# Altium schematic sheet RocketShield_pots.SchDoc
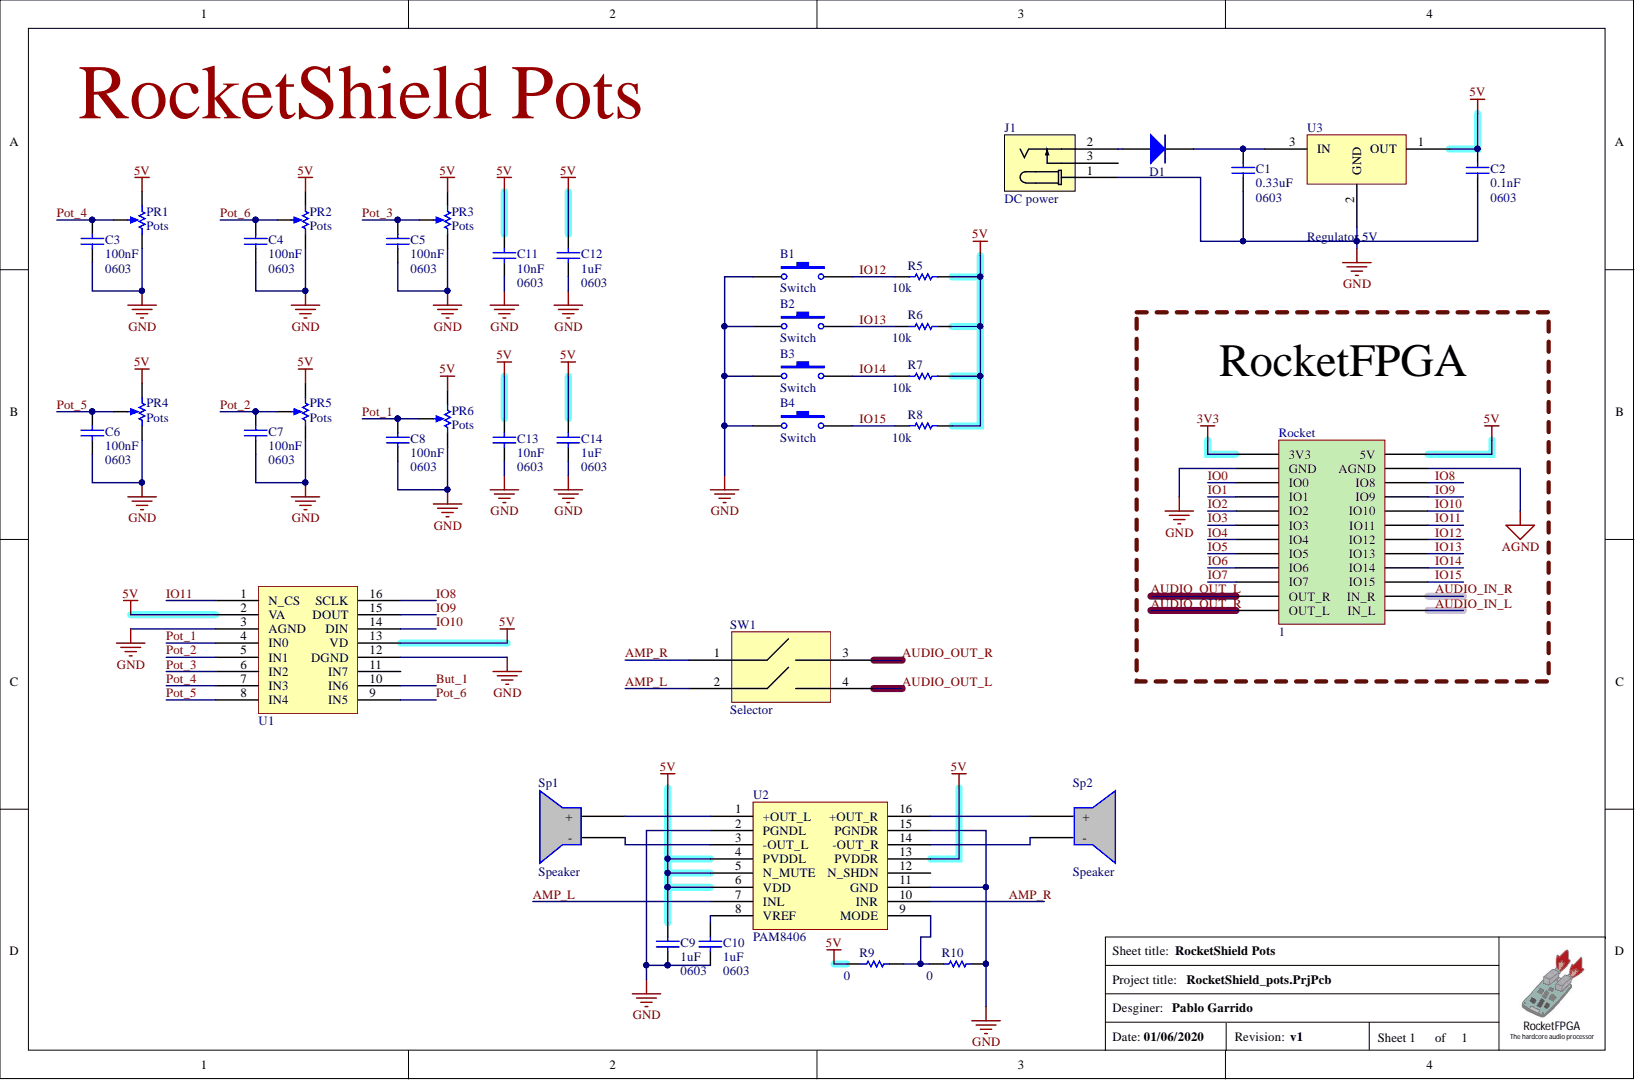
[diagram p0: RocketShield_pots.SchDoc]

## Parts
B1  Switch  [Switch]
B2  Switch  [Switch]
B3  Switch  [Switch]
B4  Switch  [Switch]
C1  value 0.33uF  Package: 0603  [0603 CAP]  (hidden comment: Ceramic capacitor)
C2  value 0.1nF  Package: 0603  [0603 CAP]  (hidden comment: Ceramic capacitor)
C3  value 100nF  Package: 0603  [0603 CAP]  (hidden comment: Ceramic capacitor)
C4  value 100nF  Package: 0603  [0603 CAP]  (hidden comment: Ceramic capacitor)
C5  value 100nF  Package: 0603  [0603 CAP]  (hidden comment: Ceramic capacitor)
C6  value 100nF  Package: 0603  [0603 CAP]  (hidden comment: Ceramic capacitor)
C7  value 100nF  Package: 0603  [0603 CAP]  (hidden comment: Ceramic capacitor)
C8  value 100nF  Package: 0603  [0603 CAP]  (hidden comment: Ceramic capacitor)
C9  value 1uF  Package: 0603  [0603 CAP]  (hidden comment: Ceramic capacitor)
C10  value 1uF  Package: 0603  [0603 CAP]  (hidden comment: Ceramic capacitor)
C11  value 10nF  Package: 0603  [0603 CAP]  (hidden comment: Ceramic capacitor)
C12  value 1uF  Package: 0603  [0603 CAP]  (hidden comment: Ceramic capacitor)
C13  value 10nF  Package: 0603  [0603 CAP]  (hidden comment: Ceramic capacitor)
C14  value 1uF  Package: 0603  [0603 CAP]  (hidden comment: Ceramic capacitor)
D1  Diode  [AXIAL - 10.16 DIODE]  (value not drawn)
J1  DC power  [DC Power]
PR1  Pots  [RK09K1130A5R]
PR2  Pots  [RK09K1130A5R]
PR3  Pots  [RK09K1130A5R]
PR4  Pots  [RK09K1130A5R]
PR5  Pots  [RK09K1130A5R]
PR6  Pots  [RK09K1130A5R]
R5  value 10k  [0805]  (hidden comment: Resistor)
R6  value 10k  [0805]  (hidden comment: Resistor)
R7  value 10k  [0805]  (hidden comment: Resistor)
R8  value 10k  [0805]  (hidden comment: Resistor)
R9  value 0  [0805]  (hidden comment: Resistor)
R10  value 0  [0805]  (hidden comment: Resistor)
Rocket  1  [RocketFPGA]
Sp1  Speaker  [Speaker]
Sp2  Speaker  [Speaker]
SW1  Selector  [Selector]
U1  ADC128S102CIMTX/NOPB  [ADC128S102CIMTX]  (value not drawn)
U2  PAM8406  [PAM8406DR]
U3  Regulator 5V  [Regulator]

## Nets
3V3: Rocket.0(3V3)
5V: C2.2 C9.2 C11.2 C12.2 C13.2 C14.2 PR1.3 PR2.3 PR3.3 PR4.3 PR5.3 PR6.3 R5.2 R6.2 R7.2 R8.2 R9.1 Rocket.12(5V) U1.2(VA) U1.13(VD) U2.4(PVDDL) U2.5(N_MUTE) U2.6(VDD) U2.13(PVDDR) U3.1
AGND: Rocket.13(AGND)
GND: B1.1 B2.1 B3.1 B4.1 C1.1 C2.1 C3.1 C4.1 C5.1 C6.1 C7.1 C8.1 C9.1 C10.1 C11.1 C12.1 C13.1 C14.1 J1.1 PR1.1 PR2.1 PR3.1 PR4.1 PR5.1 PR6.1 R10.2 Rocket.1(GND) U1.3(AGND) U1.12(DGND) U2.2(PGNDL) U2.11(GND) U2.15(PGNDR) U3.2
AMP_L: SW1.2 U2.7(INL)
AMP_R: SW1.1 U2.10(INR)
AUDIO_IN_L: Rocket.23(IN_L)
AUDIO_IN_R: Rocket.22(IN_R)
AUDIO_OUT_L: Rocket.10(OUT_R) SW1.4
AUDIO_OUT_R: Rocket.11(OUT_L) SW1.3
But_1: U1.10(IN6)
IO0: Rocket.2(IO0)
IO1: Rocket.3(IO1)
IO2: Rocket.4(IO2)
IO3: Rocket.5(IO3)
IO4: Rocket.6(IO4)
IO5: Rocket.7(IO5)
IO6: Rocket.8(IO6)
IO7: Rocket.9(IO7)
IO8: Rocket.14(IO8) U1.16(SCLK)
IO9: Rocket.15(IO9) U1.15(DOUT)
IO10: Rocket.16(IO10) U1.14(DIN)
IO11: Rocket.17(IO11) U1.1(N_CS)
IO12: B1.2 R5.1 Rocket.18(IO12)
IO13: B2.2 R6.1 Rocket.19(IO13)
IO14: B3.2 R7.1 Rocket.20(IO14)
IO15: B4.2 R8.1 Rocket.21(IO15)
Pot_1: C8.2 PR6.2 U1.4(IN0)
Pot_2: C7.2 PR5.2 U1.5(IN1)
Pot_3: C5.2 PR3.2 U1.6(IN2)
Pot_4: C3.2 PR1.2 U1.7(IN3)
Pot_5: C6.2 PR4.2 U1.8(IN4)
Pot_6: C4.2 PR2.2 U1.9(IN5)
NetC1_2: C1.2 D1.2 U3.3
NetC10_2: C10.2 U2.8(VREF)
NetD1_1: D1.1 J1.2
NetR9_2: R9.2 R10.1 U2.9(MODE)
NetSp1_1: Sp1.1 U2.1(+OUT_L)
NetSp1_2: Sp1.2 U2.3(-OUT_L)
NetSp2_1: Sp2.1 U2.16(+OUT_R)
NetSp2_2: Sp2.2 U2.14(-OUT_R)

Not connected: J1 3; U1 11(IN7); U2 12(N_SHDN)

## Library data of the parts
B1-B4 = Switch: Vendor: LCSC; Component Kind: Standard; Description: Switch; Link: https://lcsc.com/product-detail/Tactile-Switches_SHOU-HAN-TS6610CJ_C557627.html; Library Name: RocketShield_pots.SchLib
C1-C14 = Capacitor: Component Kind: Standard; Description: Capacitor; ManufacturerReference: -; Signal Integrity: Cap; Simulation: CAP; Supplier 1: Mouser; Supplier Part Number 1: 80-C1206C104K5R; Library Name: RocketShield_pots.SchLib
D1 = 1N4001: 1.0A rectifier; Vendor: LCSC; Component Kind: Standard; Link: https://lcsc.com/product-detail/Diodes-General-Purpose_Chongqing-Pingwei-Tech-1N4001_C360240.html; Library Name: RocketShield_pots.SchLib
J1 = DC power: Jack DC 2.1mm, 3 contactos; Vendor: LCSC; Component Kind: Standard; Link: https://lcsc.com/product-detail/Power-Connectors_GANGYUAN-DC-470-2-1GP_C194407.html; Library Name: RocketShield_pots.SchLib
PR1-PR6 = RK09K1130A5R: Vendor: LCSC; Component Kind: Standard; Link: https://lcsc.com/product-detail/Potentiometers-Variable-Resistors_ALPS-Electric-RK09K1130A5R_C209779.html; Library Name: RocketShield_pots.SchLib
Rocket = RocketFPGA: Component Kind: Standard; Library Name: RocketShield_pots.SchLib
Sp1 Sp2 = Speaker: FUET FS-1340; Vendor: LCSC; Component Kind: Standard; Link: https://lcsc.com/product-detail/Speakers_FUET-FS-1340_C482342.html; Library Name: RocketShield_pots.SchLib
SW1 = Selector: Vendor: LCSC; Component Kind: Standard; Link: https://lcsc.com/product-detail/DIP-Switches_Dongguan-Guangzhu-Industrial-Dongguan-Guangzhu-Industrial-Dongguan-Guangzhu-Industrial-DIP-switch-Dip-Switch-DSWB02LHGET_C99421.html; Library Name: RocketShield_pots.SchLib
U1 = ADC128S102CIMTX/NOPB: Vendor: LCSC; Component Kind: Standard; Link: https://lcsc.com/product-detail/Analog-To-Digital-Converters-ADCs_Texas-Instruments_ADC128S102CIMTX-NOPB_Texas-Instruments-Texas-Instruments-ADC128S102CIMTX-NOPB_C179666.html; Library Name: RocketShield_pots.SchLib
U2 = PAM8406: Vendor: LCSC; Component Kind: Standard; Link: https://lcsc.com/product-detail/Audio-Power-OpAmps_Diodes-Incorporated-PAM8406DR_C86270.html; Library Name: RocketShield_pots.SchLib
U3 = Regulator 5V: Vendor: LCSC; Component Kind: Standard; Link: https://lcsc.com/product-detail/Linear-Voltage-Regulators_UTC-Unisonic-Tech-78N05G-AB3-R_C171519.html; Library Name: RocketShield_pots.SchLib
R5-R10 = Resistor: Component Kind: Standard; Description: Resistor; Signal Integrity: Res; Simulation: RESISTOR; Library Name: RocketShield_pots.SchLib
